# Altium schematic sheet as5047u.SchDoc(as5047u)
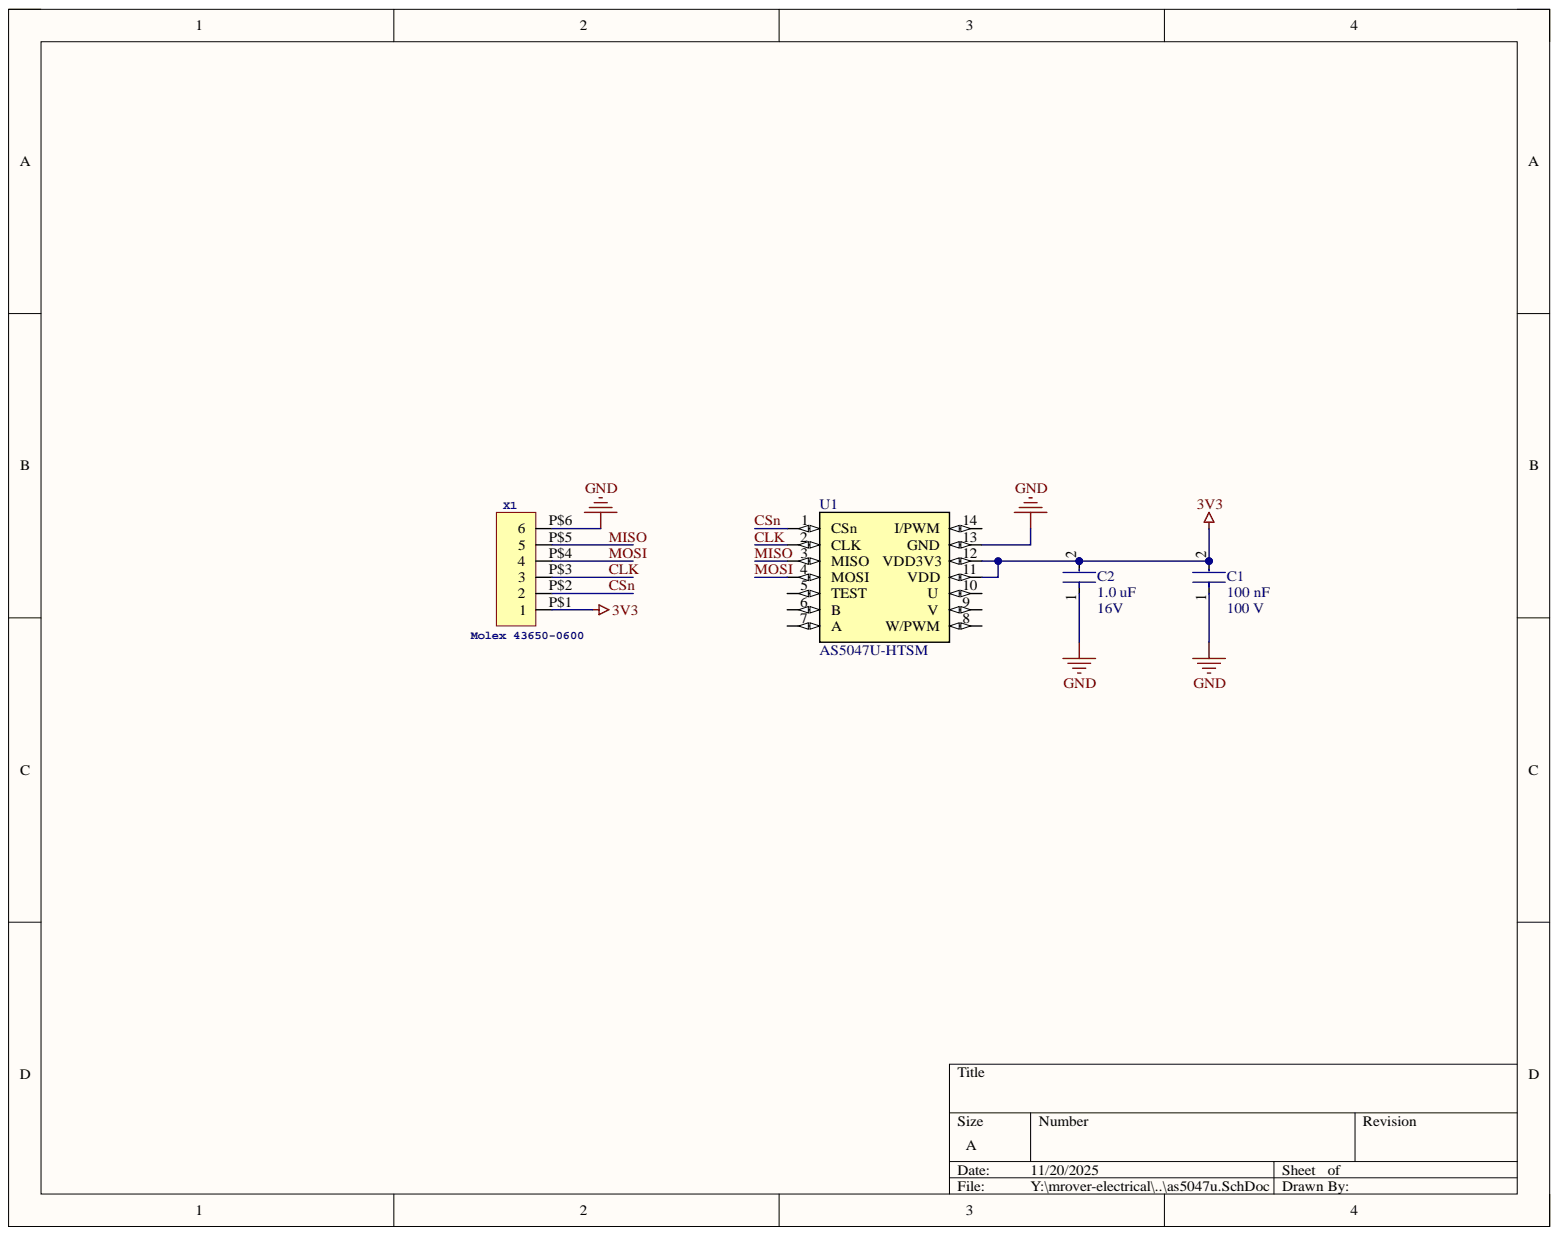
[diagram p0: as5047u.SchDoc]

## Parts
C1  CC0603KRX7R0BB104  [CAP-0603]  (value not drawn)
C2  Capacitance: 1.0 uF  Voltage: 16V  [CAP-0603]  (hidden comment: CC0603KRX7R7BB105)
U1  AS5047U-HTSM  [SOP65P640X110-14N]
X1  Molex 43650-0600  [43650-0600]

## Nets
3V3: C1.2 C2.2 U1.11(VDD) U1.12(VDD3V3) X1.P$1
GND: C1.1 C2.1 U1.13(GND) X1.P$6
CLK: U1.2(CLK) X1.P$3
CSn: U1.1(CSn) X1.P$2
MISO: U1.3(MISO) X1.P$5
MOSI: U1.4(MOSI) X1.P$4

Not connected: U1 5(TEST) 6(B) 7(A) 8(W/PWM) 9(V) 10(U) 14(I/PWM)

## Library data of the parts
C1 = CAP-100N-0001: CAP CER 0.1UF 100V X7R 0603; Capacitance: 100 nF; Component Kind: Standard; ComponentLink1Description: Datasheet; ComponentLink1URL: https://www.yageo.com/upload/media/product/app/datasheet/mlcc/upy-np0x7r_mv_100-to-630v.pdf; Device Class: Ceramic; Library Name: JLC_25.IntLib; Size: 0603; Voltage: 100 V
C2 = CAP-1U-0002: CAP CER 1UF 16V X7R 0603; Component Kind: Standard; Device Class: Ceramic; Library Name: JLC_25.IntLib; Size: 0603
U1 = IC-ABSENC-0001: Hall Effect Sensor Rotary Position External Magnet, Not Included Gull Wing; MF: ams; MP: AS5047D-ATSM; Check_prices: https://www.snapeda.com/parts/AS5047D-ATSM/ams/view-part/?ref=eda; Component Kind: Standard; ComponentLink1Description: Datasheet; ComponentLink1URL: https://www.mouser.com/datasheet/3/5912/1/AS5047U_DS000637_1_01.pdf; Library Name: MasterLib.IntLib; Package: TSSOP-14 ams; SnapEDA_Link: https://www.snapeda.com/parts/AS5047D-ATSM/ams/view-part/?ref=snap
X1 = Micro-Fit Molex 6 Pin Right Angle: DeviceSetName: HEADER_POS6_?; GateName_1: G$1; SymbolName_1: HEADER_POS6; Component Kind: Standard; Description: Molex 43650-0600; DeviceName: 43650-0600; Library Name: Molex Microfit.IntLib; LibraryName: con-molex-micro-fit-3_0_2; Package: 43650-0600
